# Altium schematic sheet wls2-buzzer.SchDoc(wls2-buzzer)
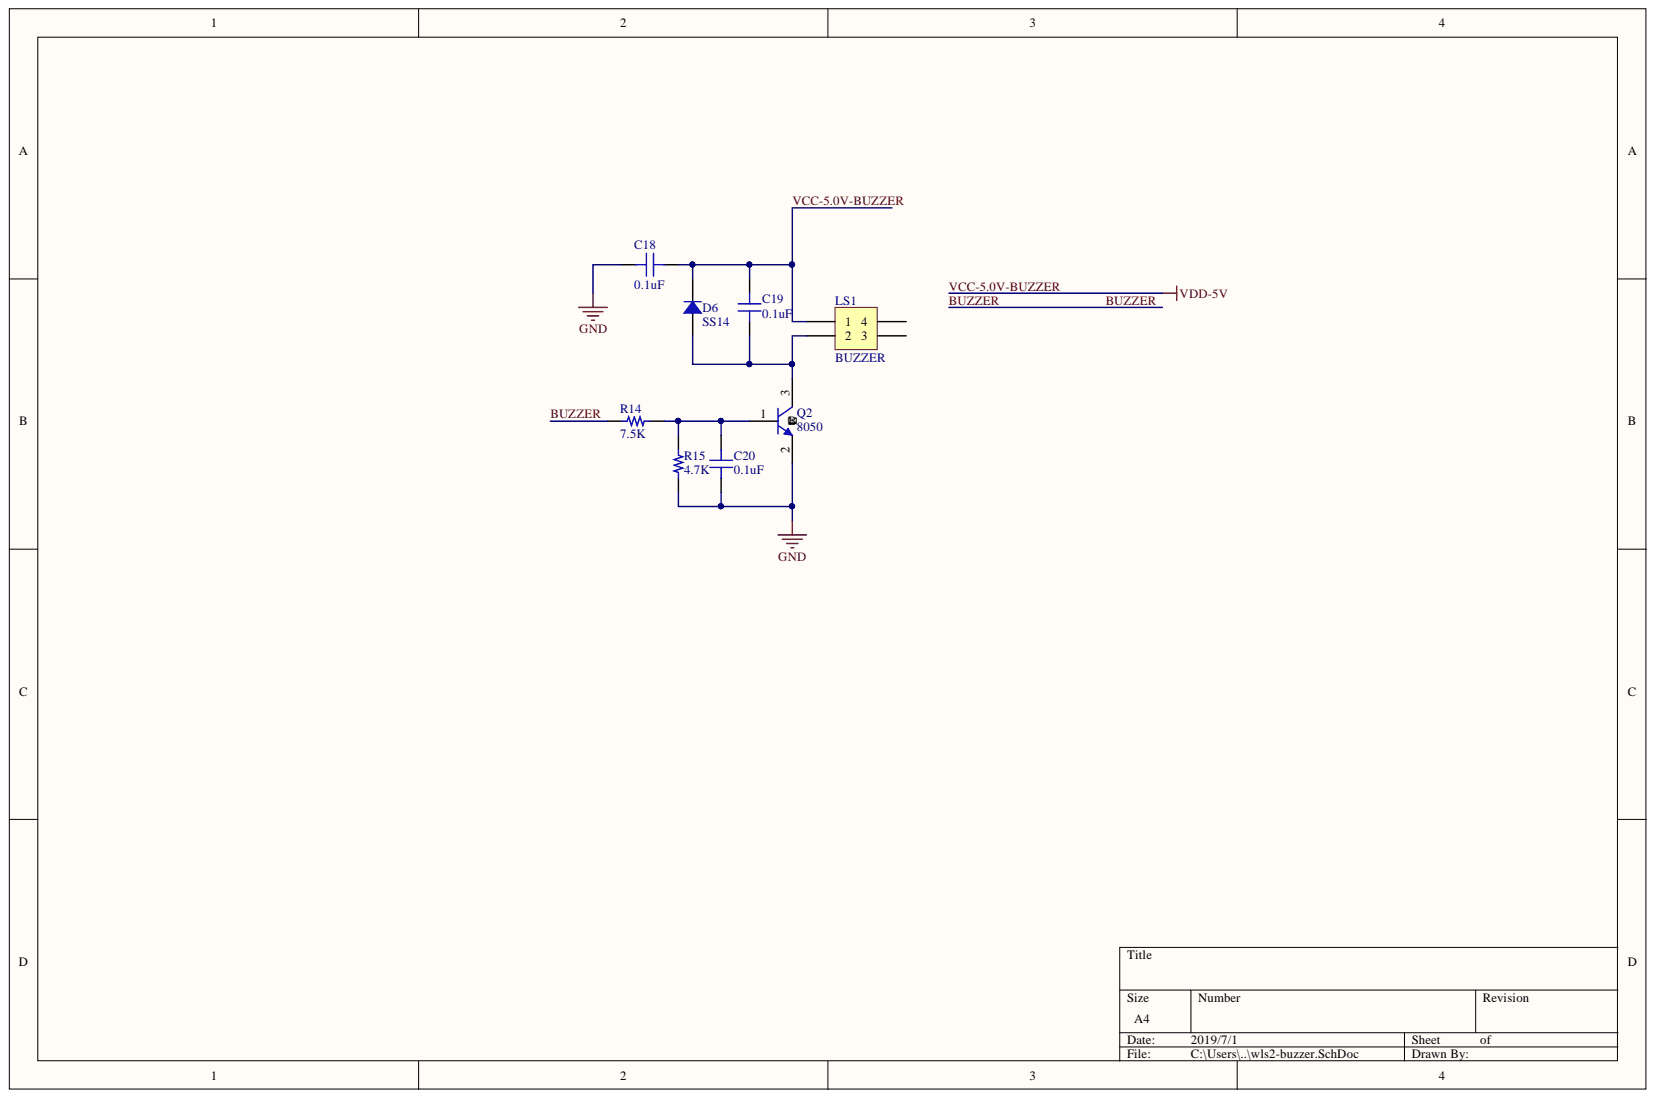
[diagram p0: wls2-buzzer.SchDoc]

## Parts
C18  0.1uF  [RES 0402/1005]
C19  0.1uF  [RES 0402/1005]
C20  0.1uF  [RES 0402/1005]
D6  SS14  [SMA-DO214AC]
LS1  BUZZER  [8503A-BEEPER]
Q2  8050  [SOT23]
R14  7.5K  [RES 0402/1005]
R15  4.7K  [RES 0402/1005]

## Nets
GND: C18.2 C20.1 Q2.2 R15.1
BUZZER: R14.1
VCC-5.0V-BUZZER: C18.1 C19.2 D6.2 LS1.1
NetC19_1: C19.1 D6.1 LS1.2 Q2.3
NetC20_2: C20.2 Q2.1 R14.2 R15.2

Not connected: LS1 3 4

## Library data of the parts
C18-C20 = Capacitor: SMT Capacitor; Component Kind: Standard; Library Name: Passives.SchLib; Value: 0.1uF
D6 = Diode: Rectifier Diode; Component Kind: Standard; Library Name: Diodes.SchLib; Part Number: SS14
R14 R15 = Resistor: SMT Resistor; Component Kind: Standard; Library Name: Passives.SchLib; TYPE: 1%
  R14: Value: 7.5K
  R15: Value: 4.7K
LS1 = BUZZER: Component Kind: Standard; Library Name: Footprint.SchLib
Q2 = 8050: Component Kind: Standard; Library Name: Footprint.SchLib
